# Altium schematic sheet 13_LED_Array.SchDoc(13_LED_Array)
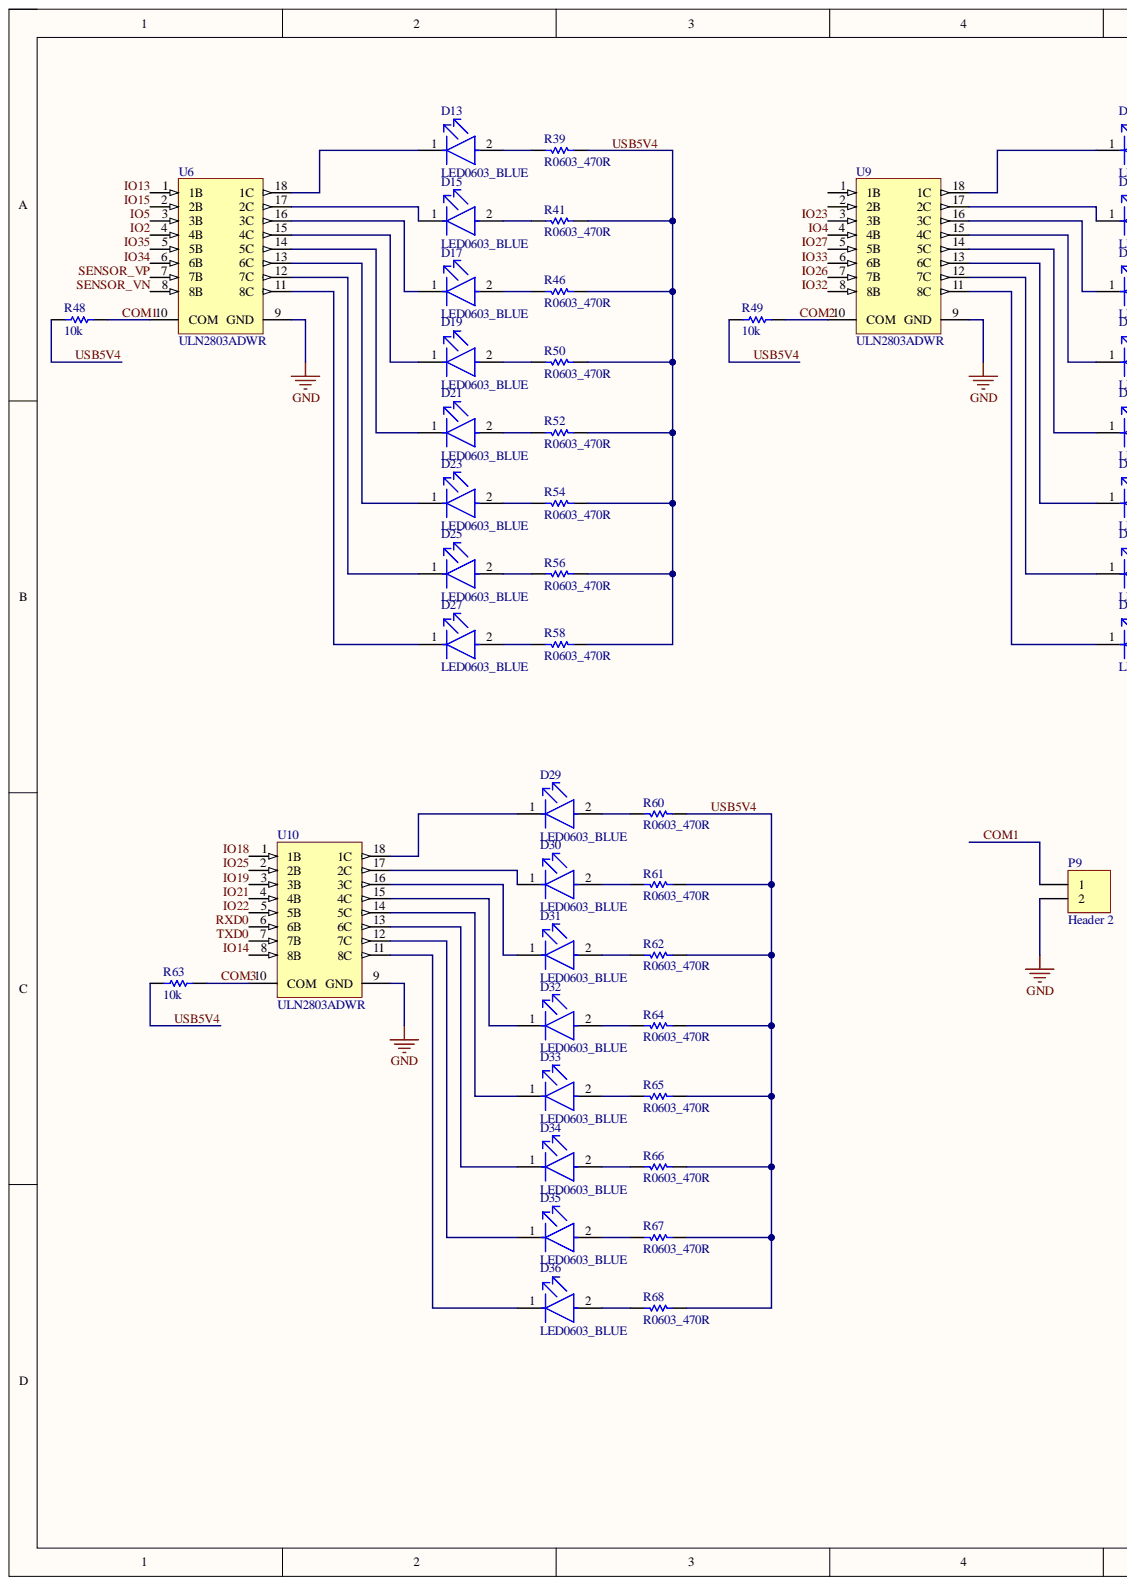
[diagram p0: 13_LED_Array.SchDoc, left]
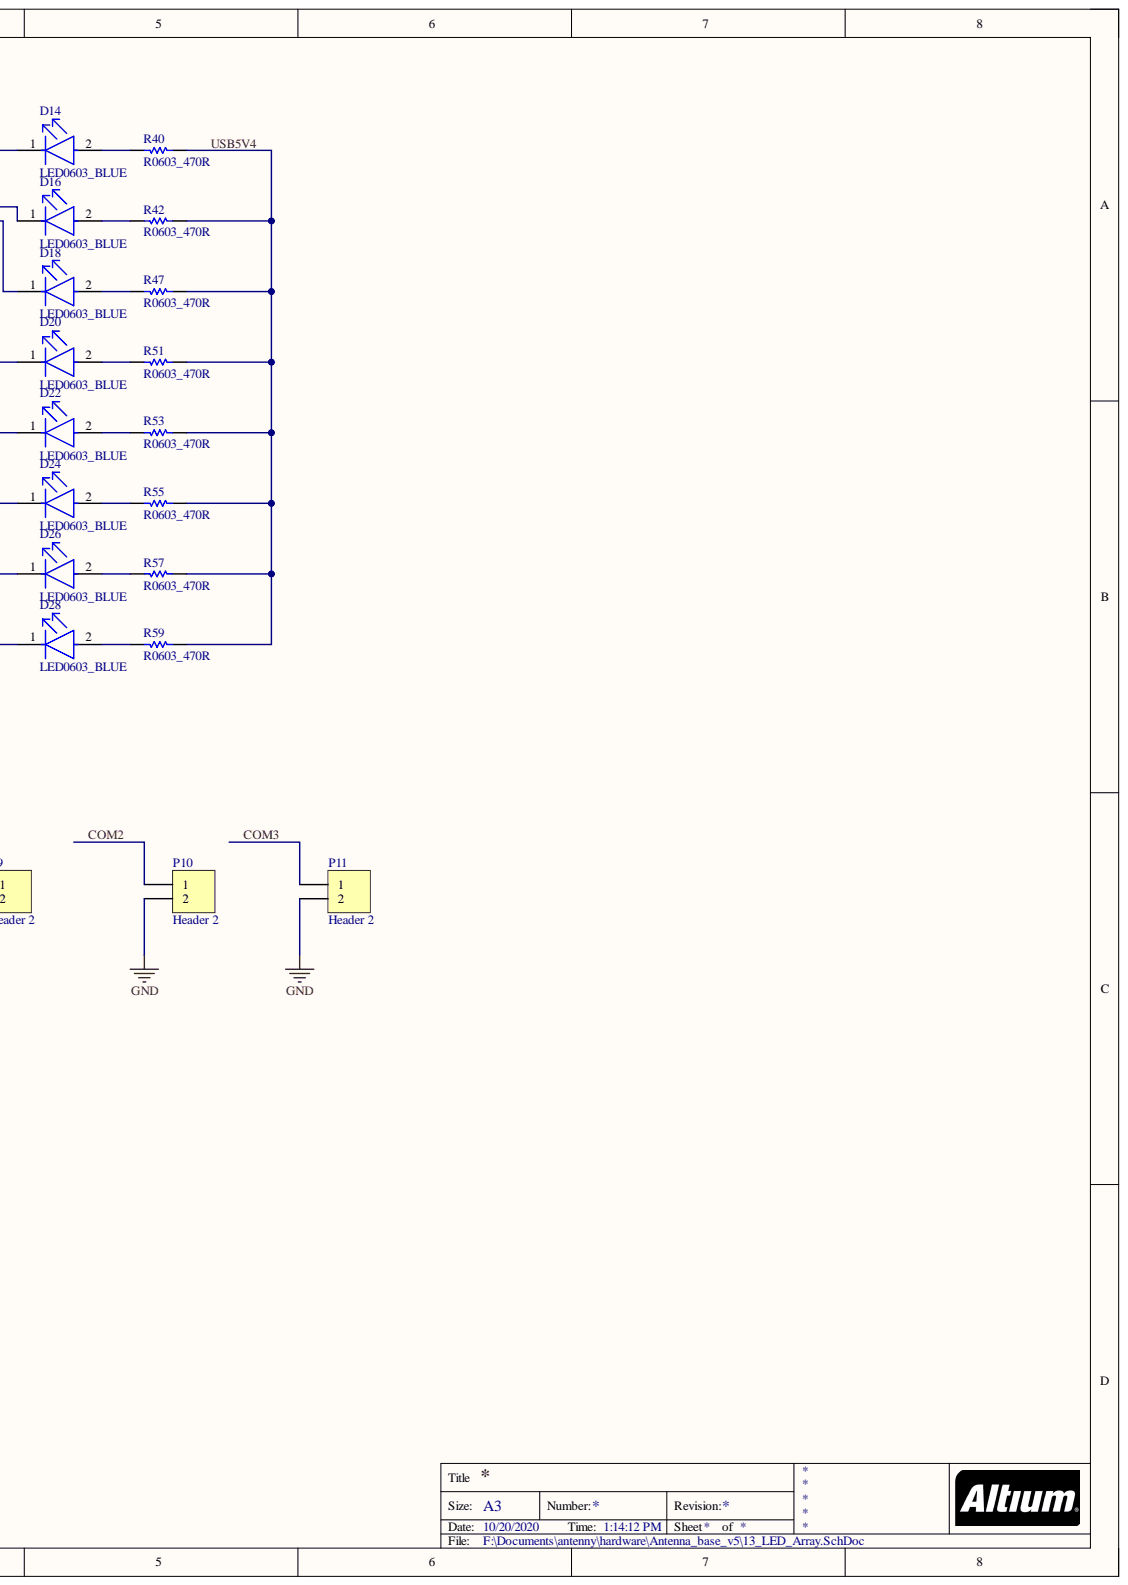
[diagram p1: 13_LED_Array.SchDoc, right]

## Parts
D13  LED0603_BLUE  [FP-LTST-C191TBKT-MFG]
D14  LED0603_BLUE  [FP-LTST-C191TBKT-MFG]
D15  LED0603_BLUE  [FP-LTST-C191TBKT-MFG]
D16  LED0603_BLUE  [FP-LTST-C191TBKT-MFG]
D17  LED0603_BLUE  [FP-LTST-C191TBKT-MFG]
D18  LED0603_BLUE  [FP-LTST-C191TBKT-MFG]
D19  LED0603_BLUE  [FP-LTST-C191TBKT-MFG]
D20  LED0603_BLUE  [FP-LTST-C191TBKT-MFG]
D21  LED0603_BLUE  [FP-LTST-C191TBKT-MFG]
D22  LED0603_BLUE  [FP-LTST-C191TBKT-MFG]
D23  LED0603_BLUE  [FP-LTST-C191TBKT-MFG]
D24  LED0603_BLUE  [FP-LTST-C191TBKT-MFG]
D25  LED0603_BLUE  [FP-LTST-C191TBKT-MFG]
D26  LED0603_BLUE  [FP-LTST-C191TBKT-MFG]
D27  LED0603_BLUE  [FP-LTST-C191TBKT-MFG]
D28  LED0603_BLUE  [FP-LTST-C191TBKT-MFG]
D29  LED0603_BLUE  [FP-LTST-C191TBKT-MFG]
D30  LED0603_BLUE  [FP-LTST-C191TBKT-MFG]
D31  LED0603_BLUE  [FP-LTST-C191TBKT-MFG]
D32  LED0603_BLUE  [FP-LTST-C191TBKT-MFG]
D33  LED0603_BLUE  [FP-LTST-C191TBKT-MFG]
D34  LED0603_BLUE  [FP-LTST-C191TBKT-MFG]
D35  LED0603_BLUE  [FP-LTST-C191TBKT-MFG]
D36  LED0603_BLUE  [FP-LTST-C191TBKT-MFG]
P9  Header 2  [HDR1X2]
P10  Header 2  [HDR1X2]
P11  Header 2  [HDR1X2]
R39  R0603_470R  [RESC1608X55X30NL15T15]
R40  R0603_470R  [RESC1608X55X30NL15T15]
R41  R0603_470R  [RESC1608X55X30NL15T15]
R42  R0603_470R  [RESC1608X55X30NL15T15]
R46  R0603_470R  [RESC1608X55X30NL15T15]
R47  R0603_470R  [RESC1608X55X30NL15T15]
R48  value 10k  [RESC1609X55X30LL15T15]  (hidden comment: R0603_10K)
R49  value 10k  [RESC1609X55X30LL15T15]  (hidden comment: R0603_10K)
R50  R0603_470R  [RESC1608X55X30NL15T15]
R51  R0603_470R  [RESC1608X55X30NL15T15]
R52  R0603_470R  [RESC1608X55X30NL15T15]
R53  R0603_470R  [RESC1608X55X30NL15T15]
R54  R0603_470R  [RESC1608X55X30NL15T15]
R55  R0603_470R  [RESC1608X55X30NL15T15]
R56  R0603_470R  [RESC1608X55X30NL15T15]
R57  R0603_470R  [RESC1608X55X30NL15T15]
R58  R0603_470R  [RESC1608X55X30NL15T15]
R59  R0603_470R  [RESC1608X55X30NL15T15]
R60  R0603_470R  [RESC1608X55X30NL15T15]
R61  R0603_470R  [RESC1608X55X30NL15T15]
R62  R0603_470R  [RESC1608X55X30NL15T15]
R63  value 10k  [RESC1609X55X30LL15T15]  (hidden comment: R0603_10K)
R64  R0603_470R  [RESC1608X55X30NL15T15]
R65  R0603_470R  [RESC1608X55X30NL15T15]
R66  R0603_470R  [RESC1608X55X30NL15T15]
R67  R0603_470R  [RESC1608X55X30NL15T15]
R68  R0603_470R  [RESC1608X55X30NL15T15]
U6  ULN2803ADWR  [DW0018A_L]
U9  ULN2803ADWR  [DW0018A_L]
U10  ULN2803ADWR  [DW0018A_L]

## Nets
GND: P9.2 P10.2 P11.2 U6.9(GND) U9.9(GND) U10.9(GND)
COM1: P9.1 R48.2 U6.10(COM)
COM2: P10.1 R49.2 U9.10(COM)
COM3: P11.1 R63.2 U10.10(COM)
IO2: U6.4(4B)
IO4: U9.4(4B)
IO5: U6.3(3B)
IO13: U6.1(1B)
IO14: U10.8(8B)
IO15: U6.2(2B)
IO18: U10.1(1B)
IO19: U10.3(3B)
IO21: U10.4(4B)
IO22: U10.5(5B)
IO23: U9.3(3B)
IO25: U10.2(2B)
IO26: U9.7(7B)
IO27: U9.5(5B)
IO32: U9.8(8B)
IO33: U9.6(6B)
IO34: U6.6(6B)
IO35: U6.5(5B)
RXD0: U10.6(6B)
SENSOR_VN: U6.8(8B)
SENSOR_VP: U6.7(7B)
TXD0: U10.7(7B)
USB5V4: R39.1 R40.1 R41.1 R42.1 R46.1 R47.1 R48.1 R49.1 R50.1 R51.1 R52.1 R53.1 R54.1 R55.1 R56.1 R57.1 R58.1 R59.1 R60.1 R61.1 R62.1 R63.1 R64.1 R65.1 R66.1 R67.1 R68.1
NetD13_1: D13.1 U6.18(1C)
NetD13_2: D13.2 R39.2
NetD14_1: D14.1 U9.18(1C)
NetD14_2: D14.2 R40.2
NetD15_1: D15.1 U6.17(2C)
NetD15_2: D15.2 R41.2
NetD16_1: D16.1 U9.17(2C)
NetD16_2: D16.2 R42.2
NetD17_1: D17.1 U6.16(3C)
NetD17_2: D17.2 R46.2
NetD18_1: D18.1 U9.16(3C)
NetD18_2: D18.2 R47.2
NetD19_1: D19.1 U6.15(4C)
NetD19_2: D19.2 R50.2
NetD20_1: D20.1 U9.15(4C)
NetD20_2: D20.2 R51.2
NetD21_1: D21.1 U6.14(5C)
NetD21_2: D21.2 R52.2
NetD22_1: D22.1 U9.14(5C)
NetD22_2: D22.2 R53.2
NetD23_1: D23.1 U6.13(6C)
NetD23_2: D23.2 R54.2
NetD24_1: D24.1 U9.13(6C)
NetD24_2: D24.2 R55.2
NetD25_1: D25.1 U6.12(7C)
NetD25_2: D25.2 R56.2
NetD26_1: D26.1 U9.12(7C)
NetD26_2: D26.2 R57.2
NetD27_1: D27.1 U6.11(8C)
NetD27_2: D27.2 R58.2
NetD28_1: D28.1 U9.11(8C)
NetD28_2: D28.2 R59.2
NetD29_1: D29.1 U10.18(1C)
NetD29_2: D29.2 R60.2
NetD30_1: D30.1 U10.17(2C)
NetD30_2: D30.2 R61.2
NetD31_1: D31.1 U10.16(3C)
NetD31_2: D31.2 R62.2
NetD32_1: D32.1 U10.15(4C)
NetD32_2: D32.2 R64.2
NetD33_1: D33.1 U10.14(5C)
NetD33_2: D33.2 R65.2
NetD34_1: D34.1 U10.13(6C)
NetD34_2: D34.2 R66.2
NetD35_1: D35.1 U10.12(7C)
NetD35_2: D35.2 R67.2
NetD36_1: D36.1 U10.11(8C)
NetD36_2: D36.2 R68.2

Not connected: U9 1(1B) 2(2B)

## Library data of the parts
D13-D36 = CMP-22017-000008-1: LED BLUE CLEAR CHIP SMD; Dominant Wavelength: 475nm; Forward Current: 20mA; Forward Voltage: 3.8V; Lens Color: Colorless; Lens Style: Diffused; Luminous Intensity: 180mcd; Max Power Dissipation: 75mW; Number of Elements: 1; Power Dissipation: 76mW; Reverse Voltage: 5V; Viewing Angle: 130°; Wavelength: 468nm; Case Code (Imperial): 0603; Case Code (Metric): 1608; Case/Package: 0603; Color: Blue; Component Kind: Standard; Depth: 1.6mm; Height: 0.55mm; Illumination Color: Blue; Lead Free: Lead Free; Length: 0.8mm; Lens Transparency: Clear; Library Name: Altium Content Vault; Max Operating Temperature: 80°C; Min Operating Temperature: -20°C; Mount: Surface Mount; Number of LEDs: 1; Number of Pins: 2; Package Quantity: 1; Packaging: Tape and Reel; Radiation Hardening: No; Test Current: 20mA; Width: 0.8mm
P9-P11 = Header 2: Header, 2-Pin; Component Kind: Standard; LatestRevisionDate: 17-Jul-2002; LatestRevisionNote: Re-released for DXP Platform.; Library Name: Miscellaneous Connectors.IntLib; Signal Integrity: Connector; Publisher: Altium Limited
R39-R42 R46 R47 R50-R62 R64-R68 = CMP-2000-00795-1: Manufacturer: Panasonic; Part Number: ERJ-3GEYJ471V; Value: 470; Component Kind: Standard; Library Name: Altium Content Vault
R48 R49 R63 = CMP-2000-07452-1: Chip Resistor, 10 KOhm, +/- 1%, 0.1 W, -55 to 155 degC, 0603 (1608 Metric), RoHS, Tape and Reel; Manufacturer: Panasonic; Case\/Package: 0603; Max Power Dissipation: 100mW; Resistance: 10KOhm; Temperature Coefficient: 100ppm\/degreesC; Voltage Rating: 75V; Case Code (Imperial): 0603; Case Code (Metric): 1608; Component Kind: Standard; Composition: ThickFilm; Contact Plating: Tin; Datasheet URL: https://industrial.panasonic.com/cdbs/www-data/pdf/RDA0000/AOA0000C304.pdf; Datasheet Version: 02/2017; Depth: 0.8mm; Height: 0.45mm; Lead Free: LeadFree; Length: 1.6mm; Library Name: Altium Content Vault; Manufacturer URL: http://www.panasonic.com/; Max Operating Temperature: 155degC; Military Standard: Not; Min Operating Temperature: -55degC; Mount: SurfaceMount; Mounting Technology: SurfaceMount; Number of Pins: 2; Number of Terminations: 2; Package Description: 2-Pin Surface Mount Device, Body 1.6 x 0.85 mm; Package Quantity: 1; Package Reference: 603; Packaging: TapeandReel; Power Rating: 100mW; Radiation Hardening: No; REACH SVHC: NoSVHC; RoHSCompliant: true; Termination: SMD/SMT; Tolerance: 1%; Voltage Rating (DC): 75V; Width: 0.031inch
U6 U9 U10 = CMP-2000-07354-1: Darlington Transistor Array, -40 to 85 degC, 18-Pin SOIC (DW), Green (RoHS & no Sb/Br), Tape and Reel; Manufacturer: Texas Instruments; Dynamic Catalog: DarlingtonArrays; IR Max: 50uA; Number of Drivers/Receivers: 8/0; Other Names: 296-15777-6; Package Version: 4040000-3/G, 01/2011; VF: 1.7V; Voltage - Supply: 5V; Category: IntegratedCircuits(ICs); Component Kind: Standard; Datasheet URL: http://www.ti.com/lit/ds/symlink/uln2803a.pdf; Datasheet Version: Rev. G, 01/2015; Family: Interface-Drivers,Receivers,Transceivers; Library Name: Altium Content Vault; Manufacturer URL: http://www.ti.com/; Mounting Technology: SurfaceMount; Mounting Type: SurfaceMount; Package / Case: 18-SOIC(0.295",7.50mmWidth); Package Description: 18-Pin Plastic Small Outline, Body 11.55 x 7.5 mm, Pitch 1.27 mm; Package Reference: DW18; Package URL: http://www.ti.com/lit/ml/mpds172a/mpds172a.pdf; Packaging: Digi-Reel®; PartNumber: ULN2803A; Protocol: -; Series: -; Standard Package: 1; Supplier Device Package: 18-SOIC; Type: DarlingtonArray
